# Altium schematic sheet 03-LCD.SchDoc
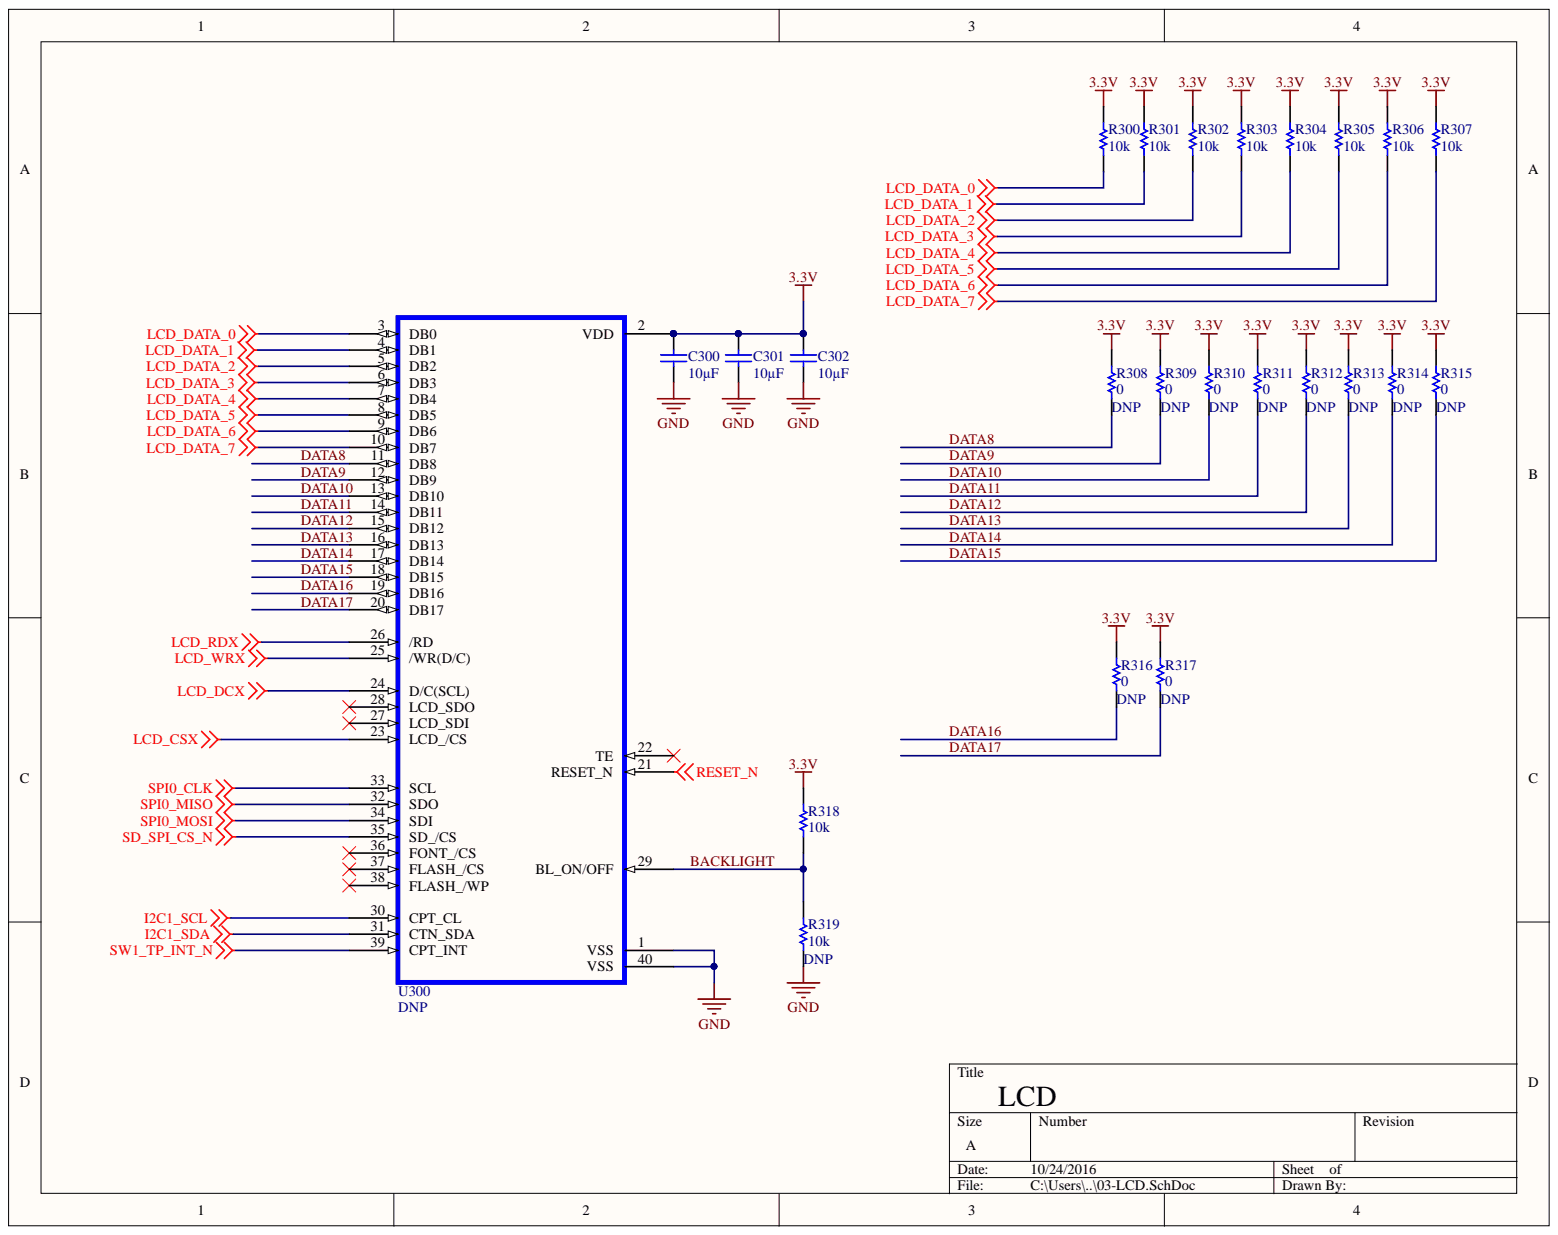
[diagram p0: 03-LCD.SchDoc]

## Parts
C300  10µF  [0805]
C301  10µF  [0805]
C302  10µF  [0805]
R300  10k  [RES_0805]
R301  10k  [RES_0805]
R302  10k  [RES_0805]
R303  10k  [RES_0805]
R304  10k  [RES_0805]
R305  10k  [RES_0805]
R306  10k  [RES_0805]
R307  10k  [RES_0805]
R308  0  Usage: DNP  [RES_0805]
R309  0  Usage: DNP  [RES_0805]
R310  0  Usage: DNP  [RES_0805]
R311  0  Usage: DNP  [RES_0805]
R312  0  Usage: DNP  [RES_0805]
R313  0  Usage: DNP  [RES_0805]
R314  0  Usage: DNP  [RES_0805]
R315  0  Usage: DNP  [RES_0805]
R316  0  Usage: DNP  [RES_0805]
R317  0  Usage: DNP  [RES_0805]
R318  10k  [RES_0805]
R319  10k  Usage: DNP  [RES_0805]
U300  Usage: DNP  [ER-TFTM032-3]  (hidden comment: ER-TFTM032-3)

## Nets
3.3V: C300.2 C301.2 C302.2 R300.2 R301.2 R302.2 R303.2 R304.2 R305.2 R306.2 R307.2 R308.2 R309.2 R310.2 R311.2 R312.2 R313.2 R314.2 R315.2 R316.2 R317.2 R318.2 U300.2(VDD)
GND: C300.1 C301.1 C302.1 R319.1 U300.1(VSS) U300.40(VSS)
I2C1_SCL: U300.30(CPT_CL)
I2C1_SDA: U300.31(CTN_SDA)
LCD_CSX: U300.23(LCD_/CS)
LCD_DATA_0: R300.1 U300.3(DB0)
LCD_DATA_1: R301.1 U300.4(DB1)
LCD_DATA_2: R302.1 U300.5(DB2)
LCD_DATA_3: R303.1 U300.6(DB3)
LCD_DATA_4: R304.1 U300.7(DB4)
LCD_DATA_5: R305.1 U300.8(DB5)
LCD_DATA_6: R306.1 U300.9(DB6)
LCD_DATA_7: R307.1 U300.10(DB7)
LCD_DCX: U300.24(D/C(SCL))
LCD_RDX: U300.26(/RD)
LCD_WRX: U300.25(/WR(D/C))
RESET_N: U300.21(RESET_N)
SD_SPI_CS_N: U300.35(SD_/CS)
SPI0_CLK: U300.33(SCL)
SPI0_MISO: U300.32(SDO)
SPI0_MOSI: U300.34(SDI)
SW1_TP_INT_N: U300.39(CPT_INT)
BACKLIGHT: R318.1 R319.2 U300.29(BL_ON/OFF)
DATA8: R308.1 U300.11(DB8)
DATA9: R309.1 U300.12(DB9)
DATA10: R310.1 U300.13(DB10)
DATA11: R311.1 U300.14(DB11)
DATA12: R312.1 U300.15(DB12)
DATA13: R313.1 U300.16(DB13)
DATA14: R314.1 U300.17(DB14)
DATA15: R315.1 U300.18(DB15)
DATA16: R316.1 U300.19(DB16)
DATA17: R317.1 U300.20(DB17)

Not connected: U300 22(TE) 27(LCD_SDI) 28(LCD_SDO) 36(FONT_/CS) 37(FLASH_/CS) 38(FLASH_/WP)

## Library data of the parts
C300-C302 = CAP_0805_U_010.000: CAP CER 10UF 16V 10% X5R 0805; Manufacturer: Murata Electronics North America; Manufacturer Part Number: GRM21BR61C106KE15L; Applications: General Purpose; Capacitance: 10µF; Category: Capacitors; Component Kind: Standard; Features: -; Height - Seated (Max): -; Lead Spacing: -; Lead Style: -; Library Name: ece353-2016.SchLib; Mounting Type: Surface Mount, MLCC; Operating Temperature: -55°C ~ 85°C; Package / Case: 0805 (2012 Metric); Packaging: Cut Tape (CT); Ratings: -; RoHS: RoHS Compliant; Size / Dimension: 0.079" L x 0.049" W (2.00mm x 1.25mm); Supplier: Digi-Key; Supplier 1: Digi-Key; Supplier Part Number: 490-3886-1-ND; Supplier Part Number 1: 490-3886-1-ND; Temperature Coefficient: X5R; Thickness (Max): 0.053" (1.35mm); Tolerance: ±10%; Value: 10µF; Voltage - Rated: 16V
R300-R307 R318 R319 = RES_0805_K_010.00: RES 10K 1/10W 1% 0805 SMD; Manufacturer: Panasonic Electronic Components; Manufacturer Part Number: ERJ-6ENF1002V; Power (Watts): 0.125W, 1/8W; Temperature Coefficient: ±100ppm/°C; Category: Resistors; Component Kind: Standard; Composition: Thick Film; Features: Automotive AEC-Q200; Height: 0.028" (0.70mm); Library Name: ece353-2016.SchLib; Number of Terminations: 2; Operating Temperature: -55°C ~ 155°C; Package / Case: 0805 (2012 Metric); Packaging: Cut Tape (CT); Resistance: 10k; RoHS: RoHS Compliant; Size / Dimension: 0.079" L x 0.049" W (2.00mm x 1.25mm); Supplier: Digi-Key; Supplier 1: Digi-Key; Supplier Device Package: 0805; Supplier Part Number: P10.0KCCT-ND; Supplier Part Number 1: P10.0KCCT-ND; Tolerance: ±1%; Value: 10k
R308-R317 = RES_0805_000.00: RES 0, , 1%, 0.125W, 1/8W 0805; Manufacturer: Panasonic Electronic Components; Manufacturer Part Number: ERJ-6GEY0R00V; Tolerance: Jumper; Category: Resistors; Component Kind: Standard; Composition: Thick Film; Features: Automotive AEC-Q200; Height: 0.028" (0.70mm); Library Name: ece353-2016.SchLib; Number of Terminations: 2; Operating Temperature: -55°C ~ 155°C; Package / Case: 0805 (2012 Metric); Packaging: Cut Tape (CT); Power (Watts): 0.125W, 1/8W; Resistance: 0; RoHS: RoHS Compliant; Size / Dimension: 0.079" L x 0.049" W (2.00mm x 1.25mm); Supplier: Digi-Key; Supplier 1: Digi-Key; Supplier Device Package: 0805; Supplier Part Number: P0.0ACT-ND; Supplier Part Number 1: P0.0ACT-ND; Temperature Coefficient: -; Value: 0
U300 = ER-TFTM032-3: 3.2" LCD Screen 320x240; Component Kind: Standard; Library Name: ece353-2016.SchLib; Manufacturer Part Number: ER-TFTM032-3
